# Altium schematic sheet 1  - Connectors.SchDoc("1  - Connectors")
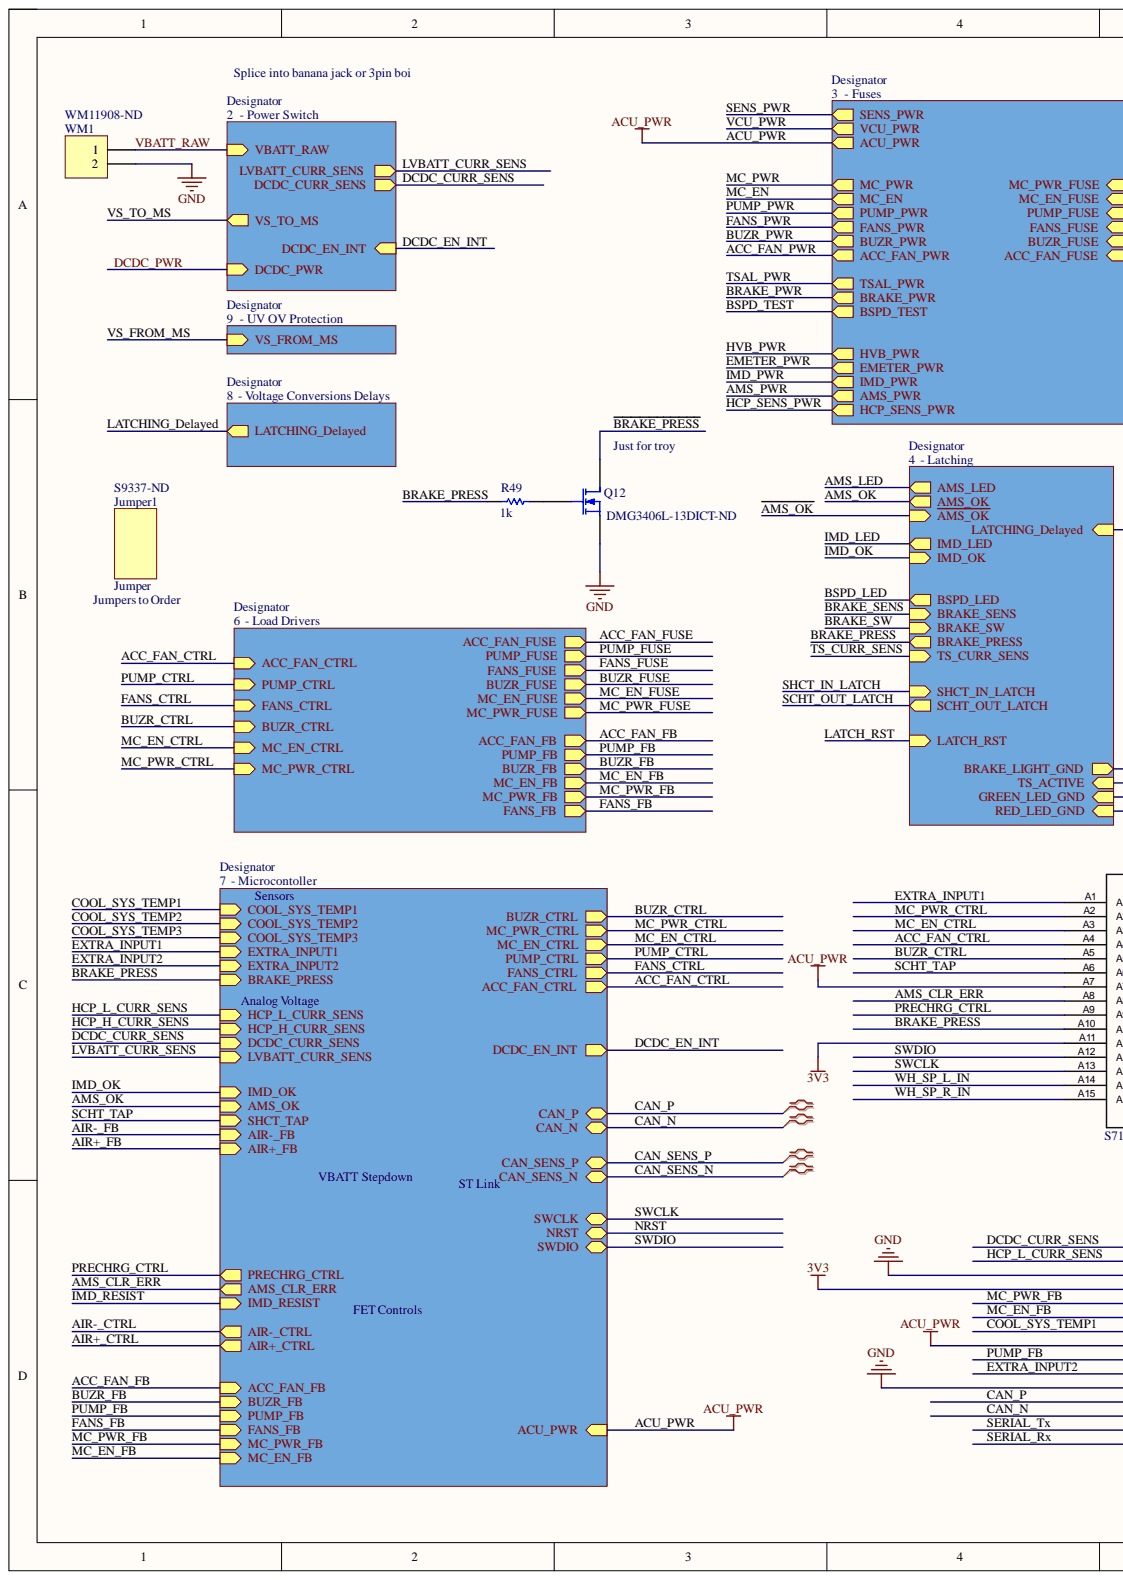
[diagram p0: 1  - Connectors.SchDoc, left]
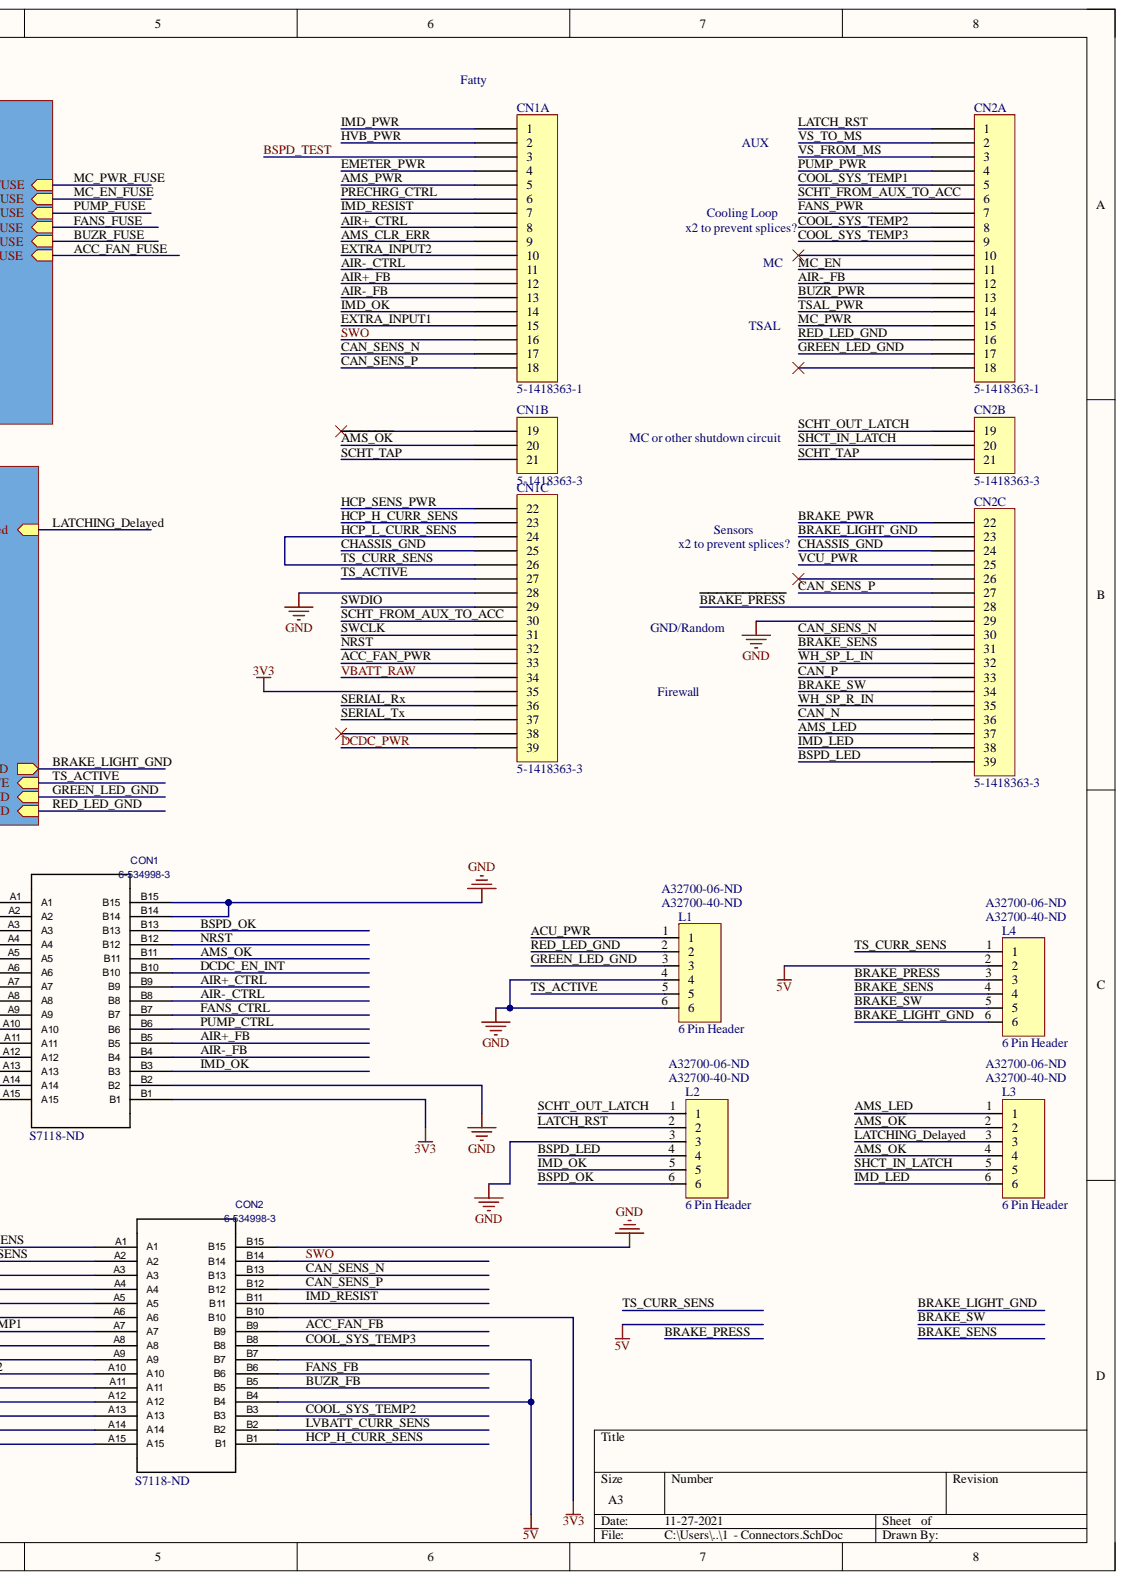
[diagram p1: 1  - Connectors.SchDoc, right]

## Parts
CN1  5-1418363-1  [LeavySeal 39 pin Ver]  (parts A,B,C)
CN2  5-1418363-1  [LeavySeal 39 pin Ver]  (parts A,B,C)
CON1  6-534998-3  Supplier Part Number 1: S7118-ND  [*TE_6-146257-5]
CON2  6-534998-3  Supplier Part Number 1: S7118-ND  [*TE_6-146257-5]
Jumper1  Jumper  Supplier Part Number 1: S9337-ND
L1  6 Pin Header  Supplier Part Number 1: A32700-40-ND  Supplier Part Number 2: A32700-06-ND  [5-146285-6]
L2  6 Pin Header  Supplier Part Number 1: A32700-40-ND  Supplier Part Number 2: A32700-06-ND  [5-146285-6]
L3  6 Pin Header  Supplier Part Number 1: A32700-40-ND  Supplier Part Number 2: A32700-06-ND  [5-146285-6]
L4  6 Pin Header  Supplier Part Number 1: A32700-40-ND  Supplier Part Number 2: A32700-06-ND  [5-146285-6]
Q12  Supplier Part Number 1: DMG3406L-13DICT-ND  [SOT-23_N]
R49  1k  [RESC0603(1608)_L]
WM1  Supplier Part Number 1: WM11908-ND  [WM9796-ND]  (hidden comment: WM9796-ND)

## Nets
3V3: CN1.35 CON1.A11 CON1.B1 CON2.A4 CON2.B10
5V: CON2.B4 CON2.B7 L4.2
GND: CN1.28 CN2.29 CON1.B2 CON1.B14 CON1.B15 CON2.A3 CON2.A11 CON2.B15 L1.4 L1.6 L2.3 Q12.2 WM1.2
~{AMS_OK}: CN1.20 L3.2
ACC_FAN_CTRL: CON1.A4
ACC_FAN_FB: CON2.B9
ACC_FAN_PWR: CN1.33
ACU_PWR: CON1.A7 CON2.A8 L1.1
AIR+_CTRL: CN1.8 CON1.B9
AIR+_FB: CN1.12 CON1.B5
AIR-_CTRL: CN1.11 CON1.B8
AIR-_FB: CN1.13 CN2.12 CON1.B4
AMS_CLR_ERR: CN1.9 CON1.A8
AMS_LED: CN2.37 L3.1
AMS_OK: CON1.B11 L3.4
AMS_PWR: CN1.5
~{BRAKE_PRESS}: CN2.28 Q12.3
BRAKE_LIGHT_GND: CN2.23 L4.6
BRAKE_PRESS: CON1.A10 L4.3 R49.1
BRAKE_PWR: CN2.22
BRAKE_SENS: CN2.31 L4.4
BRAKE_SW: CN2.34 L4.5
BSPD_LED: CN2.39 L2.4
BSPD_OK: CON1.B13 L2.6
BSPD_TEST: CN1.3
BUZR_CTRL: CON1.A5
BUZR_FB: CON2.B5
BUZR_PWR: CN2.13
CAN_N: CN2.36 CON2.A13
CAN_P: CN2.33 CON2.A12
CAN_SENS_N: CN1.17 CN2.30 CON2.B13
CAN_SENS_P: CN1.18 CN2.27 CON2.B12
CHASSIS_GND: CN1.25 CN2.24
COOL_SYS_TEMP1: CN2.5 CON2.A7
COOL_SYS_TEMP2: CN2.8 CON2.B3
COOL_SYS_TEMP3: CN2.9 CON2.B8
DCDC_CURR_SENS: CON2.A1
DCDC_EN_INT: CON1.B10
DCDC_PWR: CN1.39
EMETER_PWR: CN1.4
EXTRA_INPUT1: CN1.15 CON1.A1
EXTRA_INPUT2: CN1.10 CON2.A10
FANS_CTRL: CON1.B7
FANS_FB: CON2.B6
FANS_PWR: CN2.7
GREEN_LED_GND: CN2.17 L1.3
HCP_H_CURR_SENS: CN1.23 CON2.B1
HCP_L_CURR_SENS: CN1.24 CN1.26 CON2.A2 L4.1
HCP_SENS_PWR: CN1.22
HVB_PWR: CN1.2
IMD_LED: CN2.38 L3.6
IMD_OK: CN1.14 CON1.B3 L2.5
IMD_PWR: CN1.1
IMD_RESIST: CN1.7 CON2.B11
LATCH_RST: CN2.1 L2.2
LATCHING_Delayed: L3.3
LVBATT_CURR_SENS: CON2.B2
MC_EN: CN2.11
MC_EN_CTRL: CON1.A3
MC_EN_FB: CON2.A6
MC_PWR: CN2.15
MC_PWR_CTRL: CON1.A2
MC_PWR_FB: CON2.A5
NRST: CN1.32 CON1.B12
PRECHRG_CTRL: CN1.6 CON1.A9
PUMP_CTRL: CON1.B6
PUMP_FB: CON2.A9
PUMP_PWR: CN2.4
RED_LED_GND: CN2.16 L1.2
SCHT_FROM_AUX_TO_ACC: CN1.30 CN2.6
SCHT_OUT_LATCH: CN2.19 L2.1
SCHT_TAP: CN1.21 CN2.21 CON1.A6
SERIAL_Rx: CN1.36 CON2.A15
SERIAL_Tx: CN1.37 CON2.A14
SHCT_IN_LATCH: CN2.20 L3.5
SWCLK: CN1.31 CON1.A13
SWDIO: CN1.29 CON1.A12
SWO: CN1.16 CON2.B14
TS_ACTIVE: CN1.27 L1.5
TSAL_PWR: CN2.14
VBATT_RAW: CN1.34 WM1.1
VCU_PWR: CN2.25
VS_FROM_MS: CN2.3
VS_TO_MS: CN2.2
WH_SP_L_IN: CN2.32 CON1.A14
WH_SP_R_IN: CN2.35 CON1.A15
NetCN1_19: CN1.19
NetCN1_38: CN1.38
NetCN2_10: CN2.10
NetCN2_18: CN2.18
NetCN2_26: CN2.26
NetQ12_1: Q12.1 R49.2
ACC_FAN_FUSE:
BUZR_FUSE:
FANS_FUSE:
MC_EN_FUSE:
MC_PWR_FUSE:
PUMP_FUSE:
SENS_PWR:

## Library data of the parts
CN1 CN2 = 5-1418363-3: Levyseal Vertical 39 pin header; Component Kind: Standard; Library Name: ACB_lib.SchLib
CON1 CON2 = 6-534998-3: Built_By: EMA_UL_Team; C: Copyright (C) 2015 Accelerated Designs. All rights reserved; Vendor: TE Connectivity; Component Kind: Standard; Manufacturer_Part_Number: 6-534998-3; Supplier 1: Digi-Key; Value: 6-534998-3; Library Name: ePBR22_ACU_Controller.SCHLIB
Q12 = MOSFET-N: N-Channel MOSFET; Manufacturer: Infineon Technologies; Current - Continuous Drain (Id) @ 25°C: 50A (Tc); Drain to Source Voltage (Vdss): 30V; FET Feature: Logic Level Gate; FET Type: MOSFET N-Channel, Metal Oxide; Gate Charge (Qg) @ Vgs: 18nC @ 10V; Input Capacitance (Ciss) @ Vds: 1900pF @ 15V; Power - Max: 47W; Rds On (Max) @ Id, Vgs: 7.5 mOhm @ 30A, 10V; Vgs(th) (Max) @ Id: 2.2V @ 250µA; Category: Discrete Semiconductor Products; Component Kind: Standard; ComponentLink1Description: http://www.infineon.com/dgdl/Infineon-IPD075N03LG-DS-v02_01-en.pdf?folderId=5546d4694909da4801490a07012f053b&fileId=db3a30431936bc4b011951d824f83aeb; ComponentLink1URL: http://www.infineon.com/dgdl/Infineon-IPD075N03LG-DS-v02_01-en.pdf?folderId=5546d4694909da4801490a07012f053b&fileId=db3a30431936bc4b011951d824f83aeb; ComponentLink2Description: http://www.semicon.toshiba.co.jp/info/docget.jsp?type=datasheet&lang=en&pid=SSM3K329R; ComponentLink2URL: http://www.semicon.toshiba.co.jp/info/docget.jsp?type=datasheet&lang=en&pid=SSM3K329R; LatestRevisionDate: 17-Jul-2002; LatestRevisionNote: Re-released for DXP Platform.; Library Name: Miscellaneous Devices.IntLib; Mounting Type: Surface Mount; Package / Case: TO-252-3, DPak (2 Leads + Tab), SC-63; PackageDescription: TO, Flat Index; 3 In-Line, Axial Leads; Body Dia. 4.6mm; Lead Dia. 0.5 mm (max); PackageReference: E3; PackageVersion: 1995/1996; Packaging: Cut Tape (CT); Published: 8-Jun-2000; RoHS: RoHS Compliant; Simulation: NMOS; Supplier 1: Digi-Key; Supplier Device Package: PG-TO252-3; Publisher: Altium Limited
R49 = CMP-1591-00001-1: 0603 (1608 Metric) Chip Resistor; Case-EIA: 0603; Case-Metric: 1608; Component Kind: Standard; Library Name: Altium Content Vault; PackageReference: RESC0603(1608); Supplier 1: Digi-Key; Supplier Part Number 1: P1.00KHCT-ND; Value: 1k
WM1 = Header 2: Header, 2-Pin; Component Kind: Standard; LatestRevisionDate: 17-Jul-2002; LatestRevisionNote: Re-released for DXP Platform.; Library Name: Miscellaneous Connectors.IntLib; Signal Integrity: Connector; Supplier 1: Digi-Key; Publisher: Altium Limited
Jumper1 = Jumper: Component Kind: Standard; Supplier 1: Digi-Key; Library Name: BRAKE TEMP Components.SchLib
L1-L4 = 6 Pin Header: Component Kind: Standard; Supplier 1: Digi-Key; Supplier 2: Digi-Key; Library Name: ACB_lib.SchLib
